# Altium schematic sheet SD.SchDoc(SD)
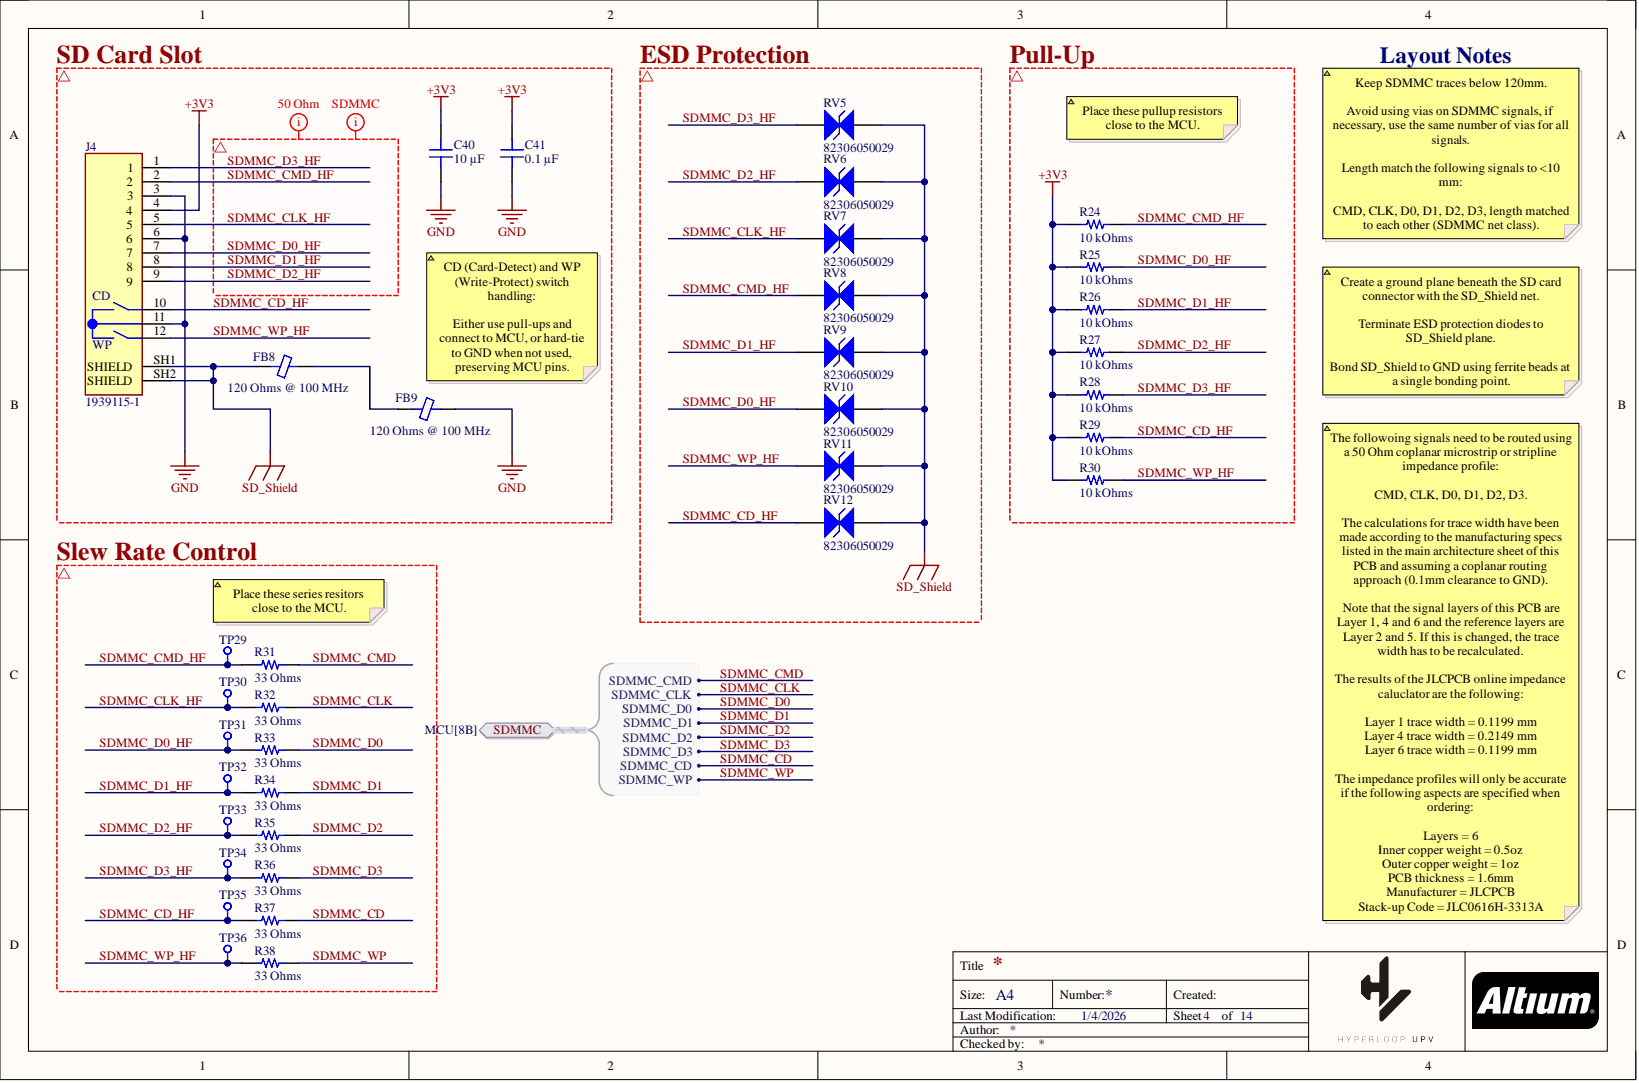
[diagram p0: SD.SchDoc]

## Parts
C40  10 µF
C41  0.1 µF
FB8  120 Ohms @ 100 MHz
FB9  120 Ohms @ 100 MHz
J4  1939115-1
R24  10 kOhms
R25  10 kOhms
R26  10 kOhms
R27  10 kOhms
R28  10 kOhms
R29  10 kOhms
R30  10 kOhms
R31  33 Ohms
R32  33 Ohms
R33  33 Ohms
R34  33 Ohms
R35  33 Ohms
R36  33 Ohms
R37  33 Ohms
R38  33 Ohms
RV5  82306050029
RV6  82306050029
RV7  82306050029
RV8  82306050029
RV9  82306050029
RV10  82306050029
RV11  82306050029
RV12  82306050029
TP29  Test point 1.2mm  (value not drawn)
TP30  Test point 1.2mm  (value not drawn)
TP31  Test point 1.2mm  (value not drawn)
TP32  Test point 1.2mm  (value not drawn)
TP33  Test point 1.2mm  (value not drawn)
TP34  Test point 1.2mm  (value not drawn)
TP35  Test point 1.2mm  (value not drawn)
TP36  Test point 1.2mm  (value not drawn)

## Ports
SDMMC, SDMMC.SDMMC_CD, SDMMC.SDMMC_CLK, SDMMC.SDMMC_CMD, SDMMC.SDMMC_D0, SDMMC.SDMMC_D1, SDMMC.SDMMC_D2, SDMMC.SDMMC_D3, SDMMC.SDMMC_WP

## Nets
+3V3: C40.2 C41.2 J4.4 R24.2 R25.2 R26.2 R27.2 R28.2 R29.2 R30.2
GND: C40.1 C41.1 FB9.2 J4.3 J4.6 J4.11
SD_Shield: FB8.1 J4.SH1(SHIELD) J4.SH2(SHIELD) RV5.2 RV6.2 RV7.2 RV8.2 RV9.2 RV10.2 RV11.2 RV12.2
SDMMC_CD: R37.1 ; port SDMMC
SDMMC_CD_HF: J4.10 R29.1 R37.2 RV12.1 TP35.1
SDMMC_CLK: R32.1 ; port SDMMC
SDMMC_CLK_HF: J4.5 R32.2 RV7.1 TP30.1
SDMMC_CMD: R31.1 ; port SDMMC
SDMMC_CMD_HF: J4.2 R24.1 R31.2 RV8.1 TP29.1
SDMMC_D0: R33.1 ; port SDMMC
SDMMC_D0_HF: J4.7 R25.1 R33.2 RV10.1 TP31.1
SDMMC_D1: R34.1 ; port SDMMC
SDMMC_D1_HF: J4.8 R26.1 R34.2 RV9.1 TP32.1
SDMMC_D2: R35.1 ; port SDMMC
SDMMC_D2_HF: J4.9 R27.1 R35.2 RV6.1 TP33.1
SDMMC_D3: R36.1 ; port SDMMC
SDMMC_D3_HF: J4.1 R28.1 R36.2 RV5.1 TP34.1
SDMMC_WP: R38.1 ; port SDMMC
SDMMC_WP_HF: J4.12 R30.1 R38.2 RV11.1 TP36.1
NetFB8_2: FB8.2 FB9.1

## Library data of the parts
C40 = CL31B106KBHNNNE: CAP CER 10UF 50V X7R 1206; manufacturer: Samsung Electro-Mechanics; Database Table Name: Capacitors - Ceramic - 1206; Tolerance: ±10%; Applications: General Purpose; Capacitance: 10 µF; Component Kind: Standard; componentlink1description: Datasheet; componentlink1url: http://www.samsungsem.com/kr/support/product-search/mlcc/CL31B106KBHNNNE.jsp; componentlink2description: DigiKey Link; componentlink2url: https://www.digikey.com/en/products/detail/samsung-electro-mechanics/CL31B106KBHNNNE/5961251; Device Package: 1206 (3216 Metric); lastupdated: 19/09/2022 13:06:52; Library Name: Celestial Altium Library - altium_library.DbLib; Lifecycle Status: Active; Minimum Order: 1; Mounting Type: Surface Mount, MLCC; Operating Temperature: -55°C ~ 125°C; Package / Case: 1206 (3216 Metric); packaging: TapeAndReel; Part Number: CL31B106KBHNNNE; Part Status: Active; partid: 40563; price: 0.06; series: CL; Size / Dimension: 0.126" L x 0.063" W (3.20mm x 1.60mm); Supplier 1: DigiKey; Supplier Part Number 1: 1276-6767-1-ND; Temperature Coefficient: X7R; Thickness (Max): 0.071" (1.80mm); Value: 10 µF; Voltage - Rated: 50V
C41 = CGA3E2X7R1H104K080AE: CAP CER 0.1UF 50V X7R 0603; manufacturer: TDK Corporation; Database Table Name: Capacitors - Ceramic - 0603; Tolerance: ±10%; Applications: Automotive, Boardflex Sensitive; Capacitance: 0.1 µF; Component Kind: Standard; componentlink1description: Datasheet; componentlink1url: https://product.tdk.com/info/en/catalog/datasheets/mlcc_automotive_soft_en.pdf?ref_disty=digikey; componentlink2description: DigiKey Link; componentlink2url: https://www.digikey.com/en/products/detail/tdk-corporation/CGA3E2X7R1H104K080AE/4490594; Device Package: 0603 (1608 Metric); Features: Soft Termination; lastupdated: 19/09/2022 13:01:18; Library Name: Celestial Altium Library - altium_library.DbLib; Lifecycle Status: Active; Minimum Order: 1; Mounting Type: Surface Mount, MLCC; Operating Temperature: -55°C ~ 125°C; Package / Case: 0603 (1608 Metric); packaging: TapeAndReel; Part Number: CGA3E2X7R1H104K080AE; Part Status: Active; partid: 2715; price: 0.01; Ratings: AEC-Q200; series: CGA; Size / Dimension: 0.063" L x 0.031" W (1.60mm x 0.80mm); Supplier 1: DigiKey; Supplier Part Number 1: 445-15957-1-ND; Temperature Coefficient: X7R; Thickness (Max): 0.037" (0.95mm); Value: 0.1 µF; Voltage - Rated: 50V
FB8 FB9 = BLM21BB121SN1D: FERRITE BEAD 120 OHM 0805 1LN; Manufacturer: Murata Electronics; Current Rating (Max): 600mA; Database Table Name: Filters - Ferrite Beads and Chips; DC Resistance (DCR) (Max): 190mOhm; Filter Type: Signal Line; Number of Lines: 1; Component Kind: Standard; ComponentLink1Description: Datasheet; ComponentLink1URL: https://www.murata.com/en-us/products/en-us/products/productdata/8796738977822/ENFA0005.pdf; ComponentLink2Description: DigiKey Link; ComponentLink2URL: https://www.digikey.com/en/products/detail/murata-electronics/BLM21BB121SN1D/584252; Device Package: 0805 (2012 Metric); Height (Max): 0.041" (1.05mm); Impedance @ Frequency: 120 Ohms @ 100 MHz; LastUpdated: 19/09/2022 13:44:16; Library Name: Celestial Altium Library - altium_library.DbLib; Lifecycle Status: Active; Minimum Order: 1; Mounting Type: Surface Mount; Operating Temperature: -55°C ~ 125°C; Package / Case: 0805 (2012 Metric); Packaging: TapeAndReel; Part Number: BLM21BB121SN1D; Part Status: Active; PartId: 1466; Price: 0.03; Series: EMIFIL®, BLM21; Size / Dimension: 0.079" L x 0.049" W (2.00mm x 1.25mm); Supplier 1: DigiKey; Supplier Part Number 1: 490-1042-1-ND; Value: 120 Ohms @ 100 MHz
J4 = CMP-1011-000000-2: Availability: Unavailable; Component Kind: Standard; Component Type: Standard; Design Item ID: 1939115-1; MP: 1939115-1; Package: None; Pin Count: 14; Price: None; Source: 1939115-1.SchLib; Library Name: Hyperloop UPV
R24-R30 = RNCS0603BKE10K0: RES 10K OHM 0.1% 1/16W 0603; Manufacturer: Stackpole Electronics Inc; Database Table Name: Resistors - Surface Mount - 0603; Power (Watts): 0.063W, 1/16W; Temperature Coefficient: ±25ppm/°C; Component Kind: Standard; ComponentLink1Description: Datasheet; ComponentLink1URL: https://www.seielect.com/catalog/sei-rncs_rnch.pdf; ComponentLink2Description: DigiKey Link; ComponentLink2URL: https://www.digikey.com/en/products/detail/stackpole-electronics-inc/RNCS0603BKE10K0/1708836; Composition: Thin Film; Device Package: 0603 (1608 Metric); Features: Anti-Corrosive, Automotive AEC-Q200, Moisture Resistant; Height - Seated (Max): 0.022" (0.55mm); LastUpdated: 09-Feb-24 20:43:37; Library Name: Celestial Altium Library - altium_library.DbLib; Lifecycle Status: Active; Minimum Order: 1; Number of Terminations: 2; Operating Temperature: -55°C ~ 155°C; Package / Case: 0603 (1608 Metric); Packaging: TapeAndReel; Part Number: RNCS0603BKE10K0; Part Status: Active; PartId: 4838; Price: 0.06; Ratings: AEC-Q200; Resistance: 10 kOhms; Series: RNCS; Size / Dimension: 0.061" L x 0.031" W (1.55mm x 0.80mm); Supplier 1: DigiKey; Supplier Device Package: 0603; Supplier Part Number 1: RNCS0603BKE10K0CT-ND; Tolerance: ±0.1%; Value: 10 kOhms
R31-R38 = CPF0603B33RE: RES SMD 33 OHM 0.1% 1/16W 0603; Manufacturer: TE Connectivity Passive Product; Database Table Name: Resistors - Surface Mount - 0603; Power (Watts): 0.063W, 1/16W; Temperature Coefficient: ±25ppm/°C; Component Kind: Standard; ComponentLink1Description: Datasheet; ComponentLink1URL: https://www.te.com/commerce/DocumentDelivery/DDEController?Action=srchrtrv&DocNm=1773200&DocType=DS&DocLang=English; ComponentLink2Description: DigiKey Link; ComponentLink2URL: https://www.digikey.com/en/products/detail/te-connectivity-passive-product/CPF0603B33RE/2381854; Composition: Thin Film; Device Package: 0603 (1608 Metric); Height - Seated (Max): 0.022" (0.55mm); LastUpdated: 09-Feb-24 20:43:37; Library Name: Celestial Altium Library - altium_library.DbLib; Lifecycle Status: Active; Minimum Order: 1; Number of Terminations: 2; Operating Temperature: -55°C ~ 155°C; Package / Case: 0603 (1608 Metric); Packaging: TapeAndReel; Part Number: CPF0603B33RE; Part Status: Active; PartId: 4881; Price: 0.09; Resistance: 33 Ohms; Series: CPF, Neohm; Size / Dimension: 0.061" L x 0.031" W (1.55mm x 0.80mm); Supplier 1: DigiKey; Supplier Device Package: 0603; Supplier Part Number 1: A119909CT-ND; Tolerance: ±0.1%; Value: 33 Ohms
RV5-RV12 = CMP-1424-00003-2: NexarPartId: 18986578; Capacitance: 200fF; Clamping Voltage: 30V; Direction: Bidirectional; Element Configuration: Single; ESD Protection: Yes; Insulation Resistance: 10MΩ; Leakage Current: 10nA; Max Reverse Leakage Current: 10mA; Number of Circuits: 1; Operating Supply Voltage: 5V; Test Current: 1A; Case Code (Imperial): 0603; Case Code (Metric): 1608; Case/Package: 0603; Component Kind: Standard; Height: 900µm; Length: 1.6mm; Library Name: Altium Content Vault; Max Operating Temperature: 85°C; Min Operating Temperature: -40°C; Mount: Surface Mount; Number of Pins: 2; Packaging: Digi-Reel®; REACH SVHC: Unknown; Reverse Standoff Voltage: 5V; RoHS: Compliant; Voltage Rating (DC): 5V; Width: 800µm; Working Voltage: 5V
TP29-TP36 = CMP-006-00250-2: 1.2mm Test point; Component Kind: Standard; Library Name: Hyperloop UPV
